# Altium schematic sheet Sheet1.SchDoc(Sheet1)
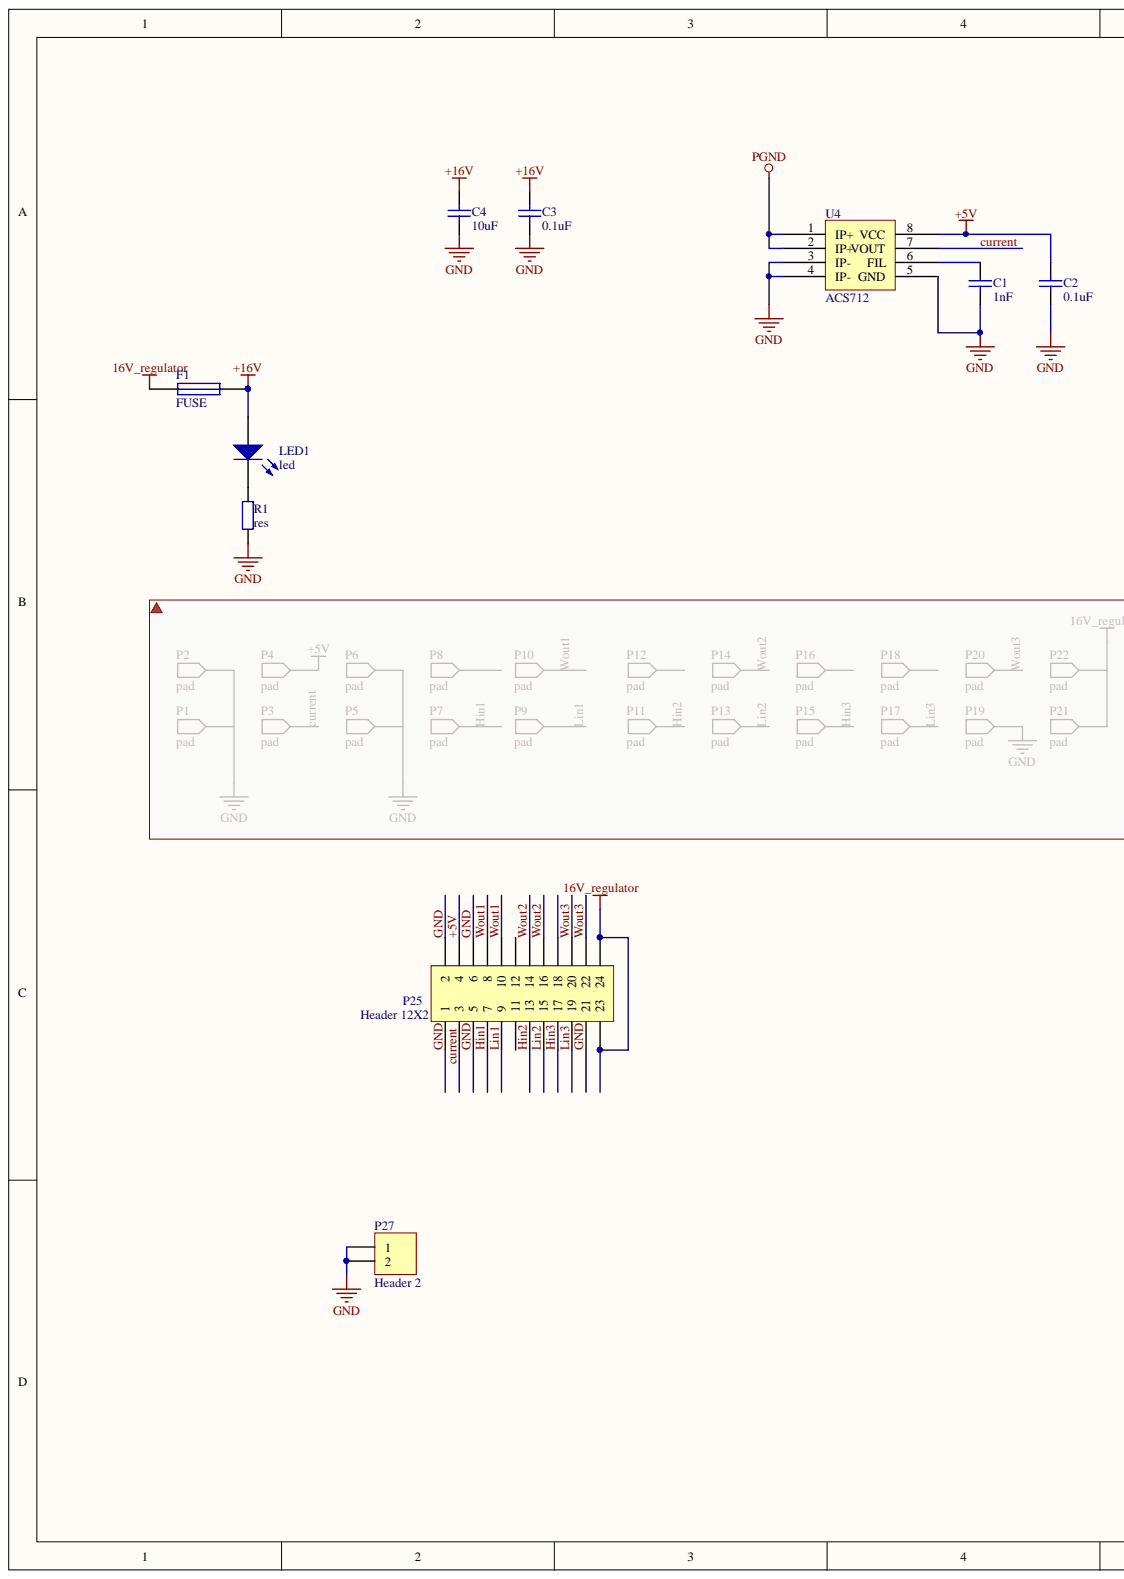
[diagram p0: Sheet1.SchDoc, left]
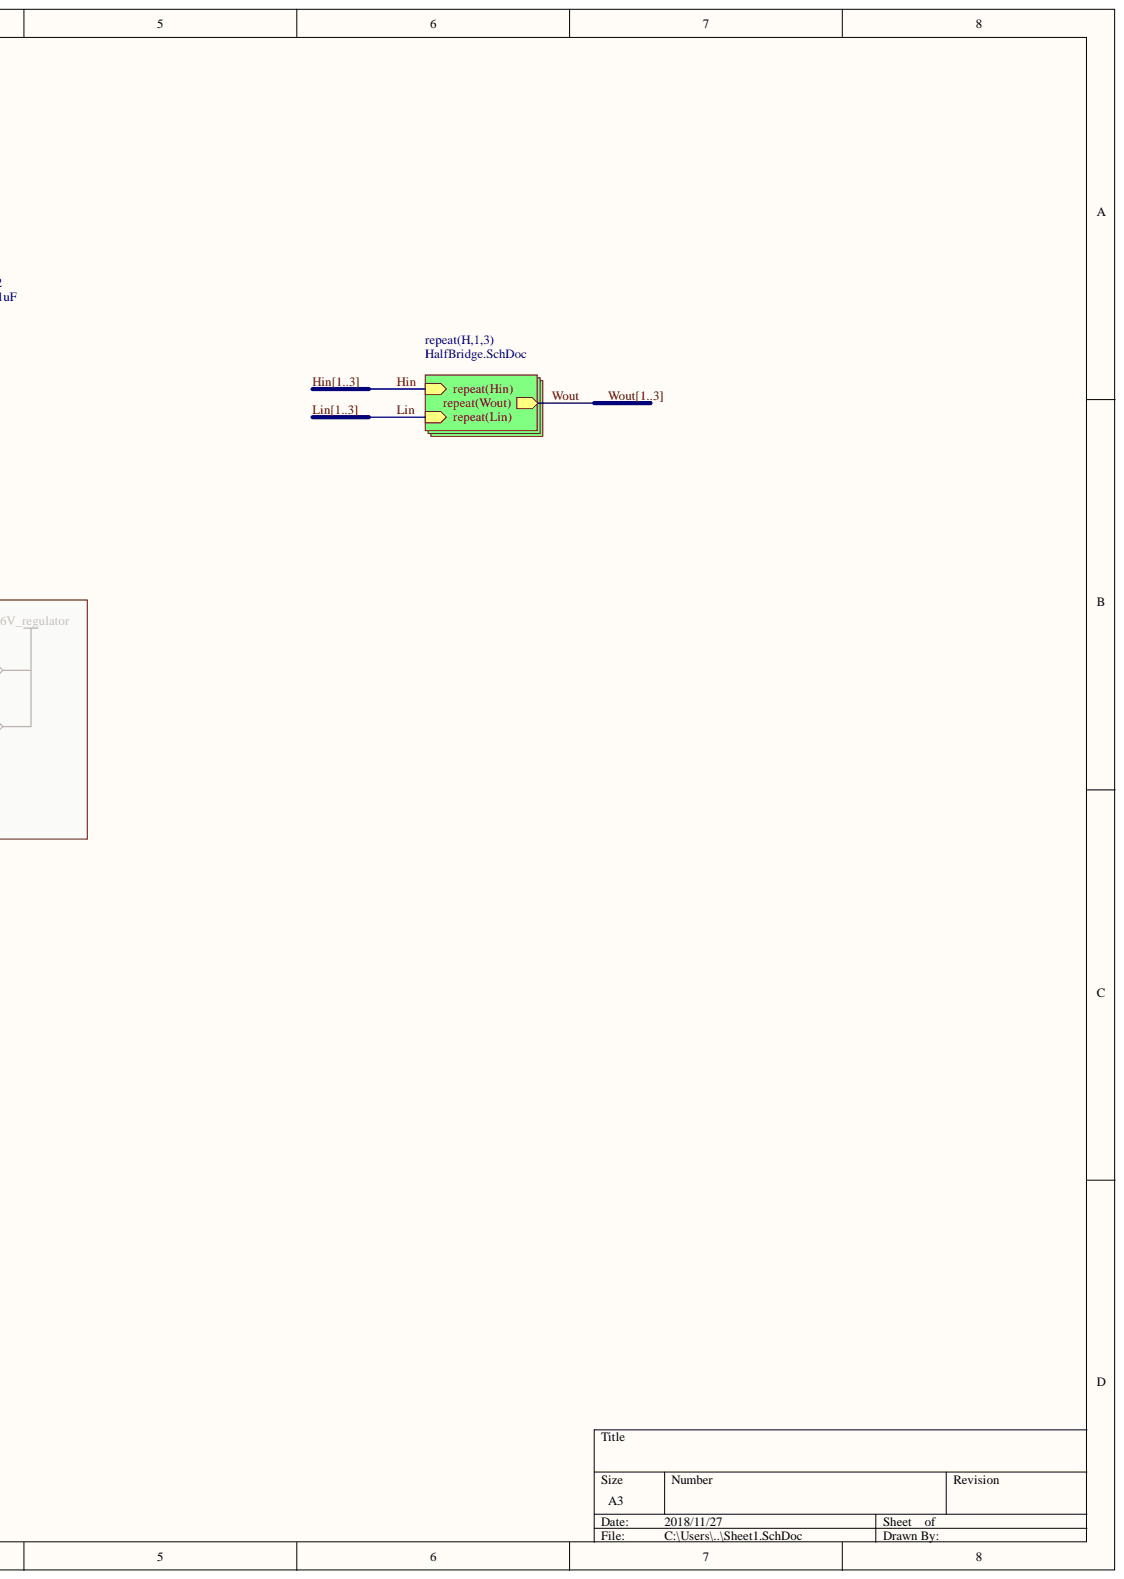
[diagram p1: Sheet1.SchDoc, right]

## Parts
C1  1nF  [0603]
C2  0.1uF  [0603]
C3  0.1uF  [0603]
C4  10uF  [0603]
F1  FUSE  [FUSE]
LED1  led  [0603_diode]
P25  Header 12X2  [HDR2X12]
P27  Header 2  [HDR1X2]
R1  res  [0603]
U4  ACS712  [SOIC-8]

## Nets
16V_regulator: F1.1 P25.23 P25.24
+16V: C3.2 C4.2 F1.2 LED1.1
PGND: U4.1(IP+) U4.2(IP+)
+5V: C2.2 P25.4 U4.8(VCC)
current: P25.3 U4.7(VOUT)
GND: C1.1 C2.1 C3.1 C4.1 P25.1 P25.2 P25.5 P25.6 P25.21 P27.1 P27.2 R1.1 U4.3(IP-) U4.4(IP-) U4.5(GND)
Hin1: P25.7  [also labelled HIN]
Hin2: P25.13  [also labelled HIN]
Hin3: P25.17  [also labelled HIN]
Lin1: P25.9  [also labelled LIN]
Lin2: P25.15  [also labelled LIN]
Lin3: P25.19  [also labelled LIN]
Wout1: P25.8 P25.10  [also labelled WOUT]
Wout2: P25.14 P25.16  [also labelled WOUT]
Wout3: P25.20 P25.22  [also labelled WOUT]
NetC1_2: C1.2 U4.6(FIL)
NetLED1_2: LED1.2 R1.2
NetP25_11: P25.11
NetP25_12: P25.12
NetP25_18: P25.18

## Library data of the parts
C1-C4 = cap: Library Name: Integrated_Library2.IntLib; Component Kind: Standard
P25 = Header 12X2: Header, 12-Pin, Dual row; Signal Integrity: Connector; Library Name: Miscellaneous Connectors.IntLib; LatestRevisionNote: Re-released for DXP Platform.; LatestRevisionDate: 17-Jul-2002; Component Kind: Standard; Publisher: Altium Limited
P27 = Header 2: Header, 2-Pin; Signal Integrity: Connector; Library Name: Miscellaneous Connectors.IntLib; LatestRevisionNote: Re-released for DXP Platform.; LatestRevisionDate: 17-Jul-2002; Component Kind: Standard; Publisher: Altium Limited
F1 = FUSE: Library Name: Integrated_Library2.IntLib; Component Kind: Standard
LED1 = led: Library Name: Integrated_Library2.IntLib; Component Kind: Standard
R1 = res: Library Name: Integrated_Library2.IntLib; Component Kind: Standard
U4 = ACS712: Library Name: Integrated_Library2.IntLib; Component Kind: Standard
